# Altium schematic sheet LCD&MIPI_DSI&USB_OTG.SchDoc(LCD&MIPI_DSI&USB_OTG)
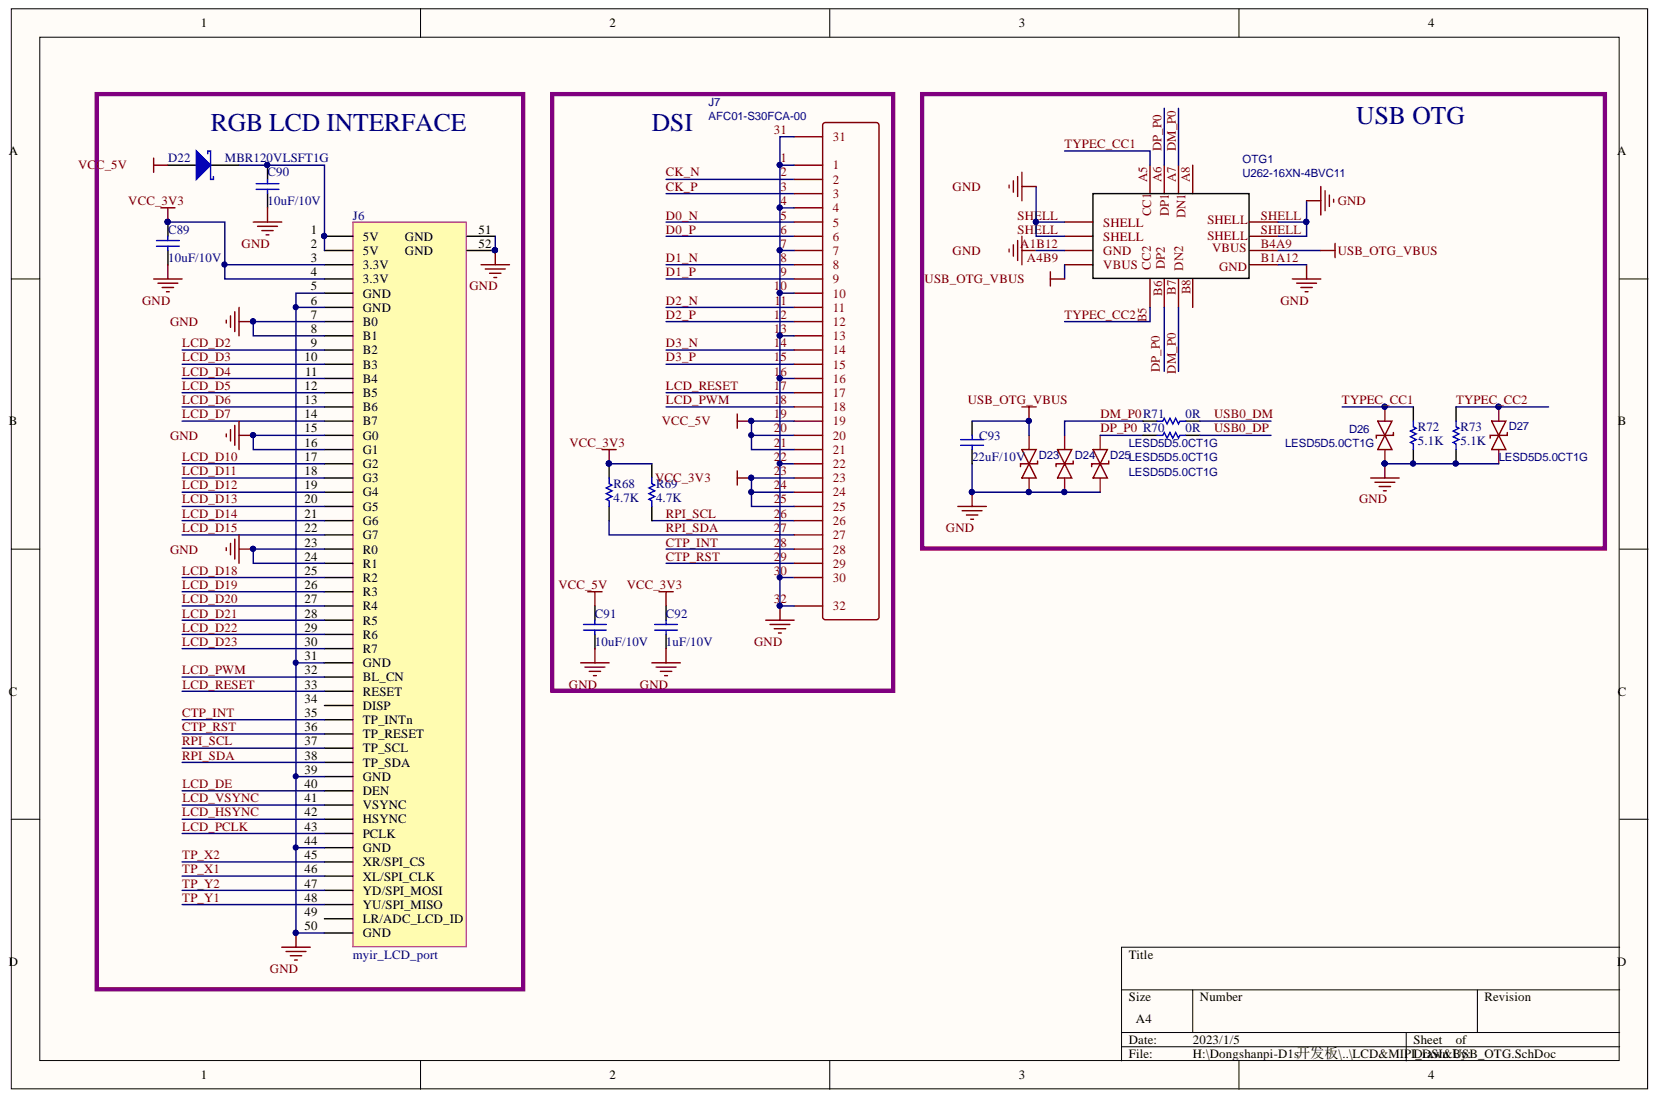
[diagram p0: LCD&MIPI_DSI&USB_OTG.SchDoc]

## Parts
C89  10uF/10V  [0603]
C90  10uF/10V  [0603]
C91  10uF/10V  [0603]
C92  1uF/10V  [0402]
C93  22uF/10V  [0603]
D22  MBR120VLSFT1G  [SOD-123_L2.8-W1.8-LS3.7-RD]
D23  LESD5D5.0CT1G  [SOD-523_L1.2-W0.8-LS1.6-BI]
D24  LESD5D5.0CT1G  [SOD-523_L1.2-W0.8-LS1.6-BI]
D25  LESD5D5.0CT1G  [SOD-523_L1.2-W0.8-LS1.6-BI]
D26  LESD5D5.0CT1G  [SOD-523_L1.2-W0.8-LS1.6-BI]
D27  LESD5D5.0CT1G  [SOD-523_L1.2-W0.8-LS1.6-BI]
J6  myir_LCD_port  [FPC50_0A5_SM_H]
J7  AFC01-S30FCA-00  [FPC-SMD_AFC01-S30FCA-00]
OTG1  U262-16XN-4BVC11  [TYPEC-12PIN - duplicate]
R68  4.7K  [0402]
R69  4.7K  [0402]
R70  0R  [0402]
R71  0R  [0402]
R72  5.1K  [0402]
R73  5.1K  [0402]

## Nets
GND: C89.1 C90.1 C91.1 C92.1 C93.1 D23.1 D24.1 D25.1 D26.1 D27.1 J6.5 J6.6 J6.7 J6.8 J6.15 J6.16 J6.23 J6.24 J6.31 J6.39 J6.44 J6.50 J6.51 J6.52 J7.1 J7.4 J7.7 J7.10 J7.13 J7.16 J7.22 J7.30 J7.31 J7.32 OTG1.A1B12 OTG1.B1A12 OTG1.SHELL R72.1 R73.1
USB_OTG_VBUS: C93.2 D23.2 OTG1.A4B9 OTG1.B4A9
VCC_3V3: C89.2 C92.2 J6.3 J6.4 J7.23 J7.24 J7.25 R68.2 R69.2
VCC_5V: C91.2 D22.1 J7.19 J7.20 J7.21
CK_N: J7.2
CK_P: J7.3
CTP_INT: J6.35 J7.28
CTP_RST: J6.36 J7.29
D0_N: J7.5
D0_P: J7.6
D1_N: J7.8
D1_P: J7.9
D2_N: J7.11
D2_P: J7.12
D3_N: J7.14
D3_P: J7.15
DM_P0: D24.2 OTG1.A7 OTG1.B7 R71.1
DP_P0: D25.2 OTG1.A6 OTG1.B6 R70.1
LCD_D2: J6.9
LCD_D3: J6.10
LCD_D4: J6.11
LCD_D5: J6.12
LCD_D6: J6.13
LCD_D7: J6.14
LCD_D10: J6.17
LCD_D11: J6.18
LCD_D12: J6.19
LCD_D13: J6.20
LCD_D14: J6.21
LCD_D15: J6.22
LCD_D18: J6.25
LCD_D19: J6.26
LCD_D20: J6.27
LCD_D21: J6.28
LCD_D22: J6.29
LCD_D23: J6.30
LCD_DE: J6.40
LCD_HSYNC: J6.42
LCD_PCLK: J6.43
LCD_PWM: J6.32 J7.18
LCD_RESET: J6.33 J7.17
LCD_VSYNC: J6.41
RPI_SCL: J6.37 J7.26 R69.1
RPI_SDA: J6.38 J7.27 R68.1
TP_X1: J6.46
TP_X2: J6.45
TP_Y1: J6.48
TP_Y2: J6.47
TYPEC_CC1: D26.2 OTG1.A5 R72.2
TYPEC_CC2: D27.2 OTG1.B5 R73.2
USB0_DM: R71.2
USB0_DP: R70.2
NetC90_2: C90.2 D22.2 J6.1 J6.2

Not connected: J6 34 49; OTG1 A8 B8

## Library data of the parts
C89-C93 = Cap: Capacitor; PartNumber: CAP100NF; Component Kind: Standard; LatestRevisionDate: 17-Jul-2002; LatestRevisionNote: Re-released for DXP Platform.; Library Name: Miscellaneous Devices.IntLib; PackageReference: 0402; Published: 8-Jun-2000; Signal Integrity: Cap; Simulation: CAP; Publisher: Altium Limited
  C89-C91: Value: 10uF/10V
  C92: Value: 1uF/10V
  C93: Value: 22uF/10V
D22 = D Schottky: Schottky Diode; Code_JEDEC: DO-214-AA; Component Kind: Standard; LatestRevisionDate: 17-Jul-2002; LatestRevisionNote: Re-released for DXP Platform.; Library Name: Miscellaneous Devices.IntLib; PackageDescription: DO-214-AA/SMB; 2 C-Bend Leads; Body 5.3 x 3.6 mm, inc. leads (LxW); PackageReference: SMB; Published: 8-Jun-2000; Signal Integrity: D Schottky; Simulation: SKYDIODE; Value: MBR120VLSFT1G; Publisher: Altium Limited
D23-D27 = LESD5D5.0CT1G: BOM_Manufacturer: Leshan Radio; Contributor: LCSC; BOM_JLCPCB Part Class: Extended Part; BOM_Manufacturer Part: LESD5D5.0CT1G; BOM_Supplier: LCSC; BOM_Supplier Part: C383211; Component Kind: Standard; spicePre: D; spiceSymbolName: LESD5D5.0CT1G
J7 = AFC01-S30FCA-00: BOM_Manufacturer: JUSHUO; Contributor: LCSC; BOM_JLCPCB Part Class: Extended Part; BOM_Manufacturer Part: AFC01-S30FCA-00; BOM_Supplier: LCSC; BOM_Supplier Part: C262671; Component Kind: Standard; spicePre: F; spiceSymbolName: AFC01-S30FCA-00
OTG1 = U262-16XN-4BVC11: BOM_Manufacturer: XKB Enterprise; Contributor: LCSC; BOM_Manufacturer Part: U262-16XN-4BVC11; BOM_Supplier: LCSC; BOM_Supplier Part: C319148; Component Kind: Standard; Library Name: HM2.SchLib; spicePre: U; spiceSymbolName: U262-16XN-4BVC11
R68 R69 = Res1: Resistor; PartNumber: RES2.2K; Component Kind: Standard; LatestRevisionDate: 17-Jul-2002; LatestRevisionNote: Re-released for DXP Platform.; Library Name: Miscellaneous Devices.IntLib; PackageReference: 0402; Published: 8-Jun-2000; Signal Integrity: Res; Simulation: RESISTOR; Value: 4.7K; Publisher: Altium Limited
R70-R73 = Res1: Resistor; PartNumber: RES22R; Component Kind: Standard; LatestRevisionDate: 17-Jul-2002; LatestRevisionNote: Re-released for DXP Platform.; Library Name: Miscellaneous Devices.IntLib; PackageReference: 0402; Published: 8-Jun-2000; Signal Integrity: Res; Simulation: RESISTOR; Publisher: Altium Limited
  R70 R71: Value: 0R
  R72 R73: Value: 5.1K
J6 = myir_LCD_port: Component Kind: Standard; Library Name: HM2.SchLib
